# Altium schematic sheet Pins.SchDoc(Pins)
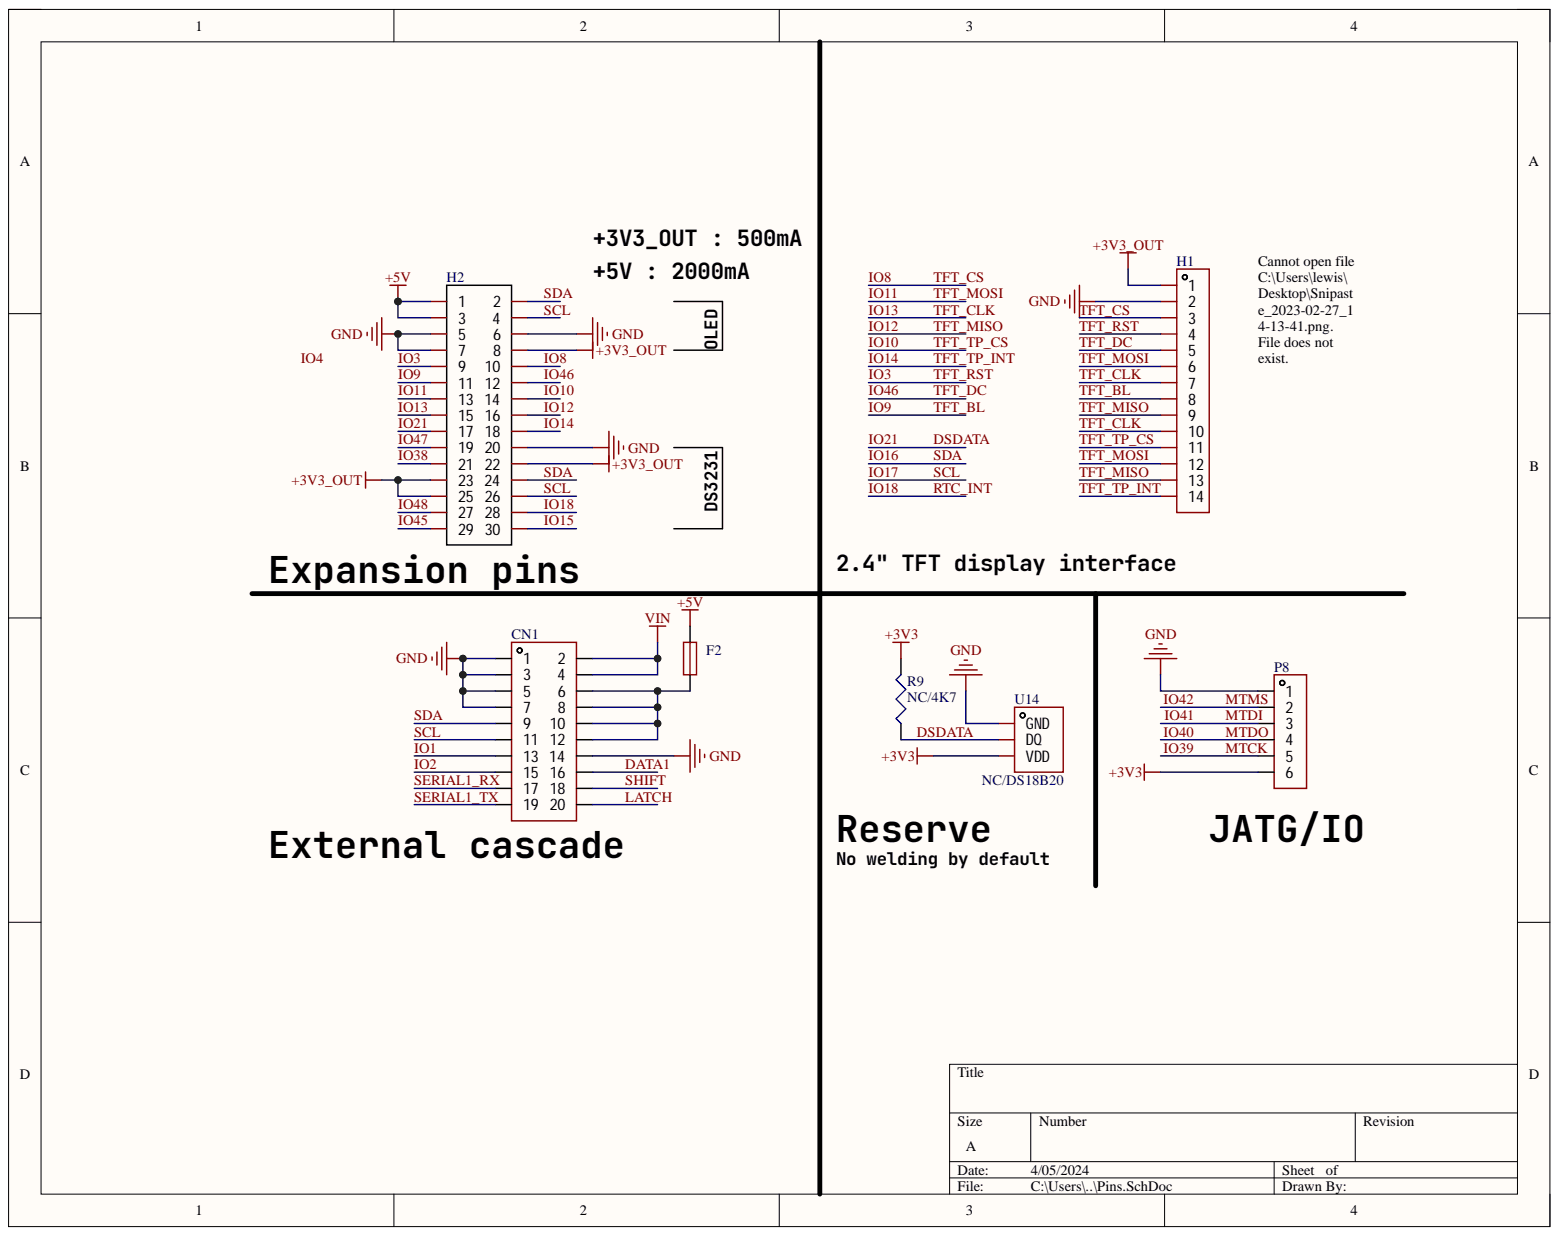
[diagram p0: Pins.SchDoc]

## Parts
CN1  321020SG0ABK00A01  [IDC-TH_20P-P2.54-V-R2-C10-S2.54]  (value not drawn)
F2  BSMD1206-200-12V  [F1206]  (value not drawn)
H1  PM254-1-14-Z-8.5  [HDR-TH_14P-P2.54-V-F-1]  (value not drawn)
H2  B-2200S30P-B120  [HDR-TH_30P-P2.54-V-F-R2-C15-S2.54-1]  (value not drawn)
P8  PZ254V-11-06P  [HDR-TH_6P-P2.54-V-M]  (value not drawn)
R9  NC/4K7  [R0603]
U14  NC/DS18B20  [TO-92-3_L4.9-W3.7-P1.27-L]

## Nets
+3V3: P8.6 R9.2 U14.3
+3V3_OUT: H1.1 H2.8 H2.22 H2.23 H2.25
+5V: F2.2 H2.1 H2.3
GND: CN1.1 CN1.3 CN1.5 CN1.7 CN1.14 H1.2 H2.5 H2.6 H2.7 H2.20 P8.1 U14.1
VIN: CN1.2 CN1.4
DATA1: CN1.16
DSDATA: H2.17 R9.1 U14.2
IO1: CN1.13
IO2: CN1.15
IO3: H1.4 H2.9
IO8: H1.3 H2.10
IO9: H1.8 H2.11
IO10: H1.11 H2.14
IO11: H1.6 H1.12 H2.13
IO12: H1.9 H1.13 H2.16
IO13: H1.7 H1.10 H2.15
IO14: H1.14 H2.18
IO15: H2.30
IO16: CN1.9 H2.2 H2.24
IO17: CN1.11 H2.4 H2.26
IO18: H2.28
IO38: H2.21
IO39: P8.5
IO40: P8.4
IO41: P8.3
IO42: P8.2
IO45: H2.29
IO46: H1.5 H2.12
IO47: H2.19
IO48: H2.27
LATCH: CN1.20
SERIAL1_RX: CN1.17
SERIAL1_TX: CN1.19
SHIFT: CN1.18
NetCN1_6: CN1.6 CN1.8 CN1.10 CN1.12 F2.1
IO4:

## Library data of the parts
CN1 = 321020SG0ABK00A01: 连接器类型:简牛座;间距:2.54mm;每排PIN数:10;排数:2;行距:2.54mm;封装形式:直插;触头材质:黄铜;触头镀层:金;; Component Kind: Standard; Library Name: 常用.SCHLIB
F2 = BSMD1206-200-12V: 最大电压:12V;最大电流:100A;保持电流:2A;跳闸电流:3.5A;消耗功率:800mW;初始态阻值(最小值):15mΩ;跳断后阻值(最大值):80mΩ;最大动作时间:1.5s;工作温度:-40℃~+85℃;; Component Kind: Standard; Library Name: 常用.SCHLIB
H2 = B-2200S30P-B120: 排数:双排;总孔位数:30;间距:2.54mm;插孔结构:2x15P;行距:2.54mm;安装类型:直插;塑高:-;触头材质:黄铜;触头镀层:金;; Component Kind: Standard; Library Name: 常用.SCHLIB
P8 = PZ254V-11-06P: 排数:单排;总PIN位数:6;间距:2.54mm;插针结构:1x6P;行距:-;安装类型:直插;圆针/方针:方针;额定电流:-;额定电压:-;配合针长度:6mm;塑高:2.5mm;端接针长度:3mm;触头材质:黄铜;触头镀层:-;工作温度范围:-40℃~+105℃;颜色:黑色;; Component Kind: Standard; Library Name: 常用.SCHLIB
R9 = Res3: Resistor; Component Kind: Standard; LatestRevisionDate: 29-May-2009; LatestRevisionNote: IPC-7351 Footprint Added.; Library Name: Miscellaneous Devices.IntLib; PackageDescription: Chip Resistor; PackageReference: J1-0603; Published: 8-Jun-2000; Signal Integrity: Res; Simulation: RESISTOR; Value: NC/4K7; Publisher: Altium Limited
U14 = DS18B20: Component Kind: Standard; Library Name: 常用.SCHLIB
H1 = PM254-1-14-Z-8.5: Component Kind: Standard; Library Name: 常用.SCHLIB
